# Altium schematic sheet 05_LVDC_SAMPLE.SchDoc
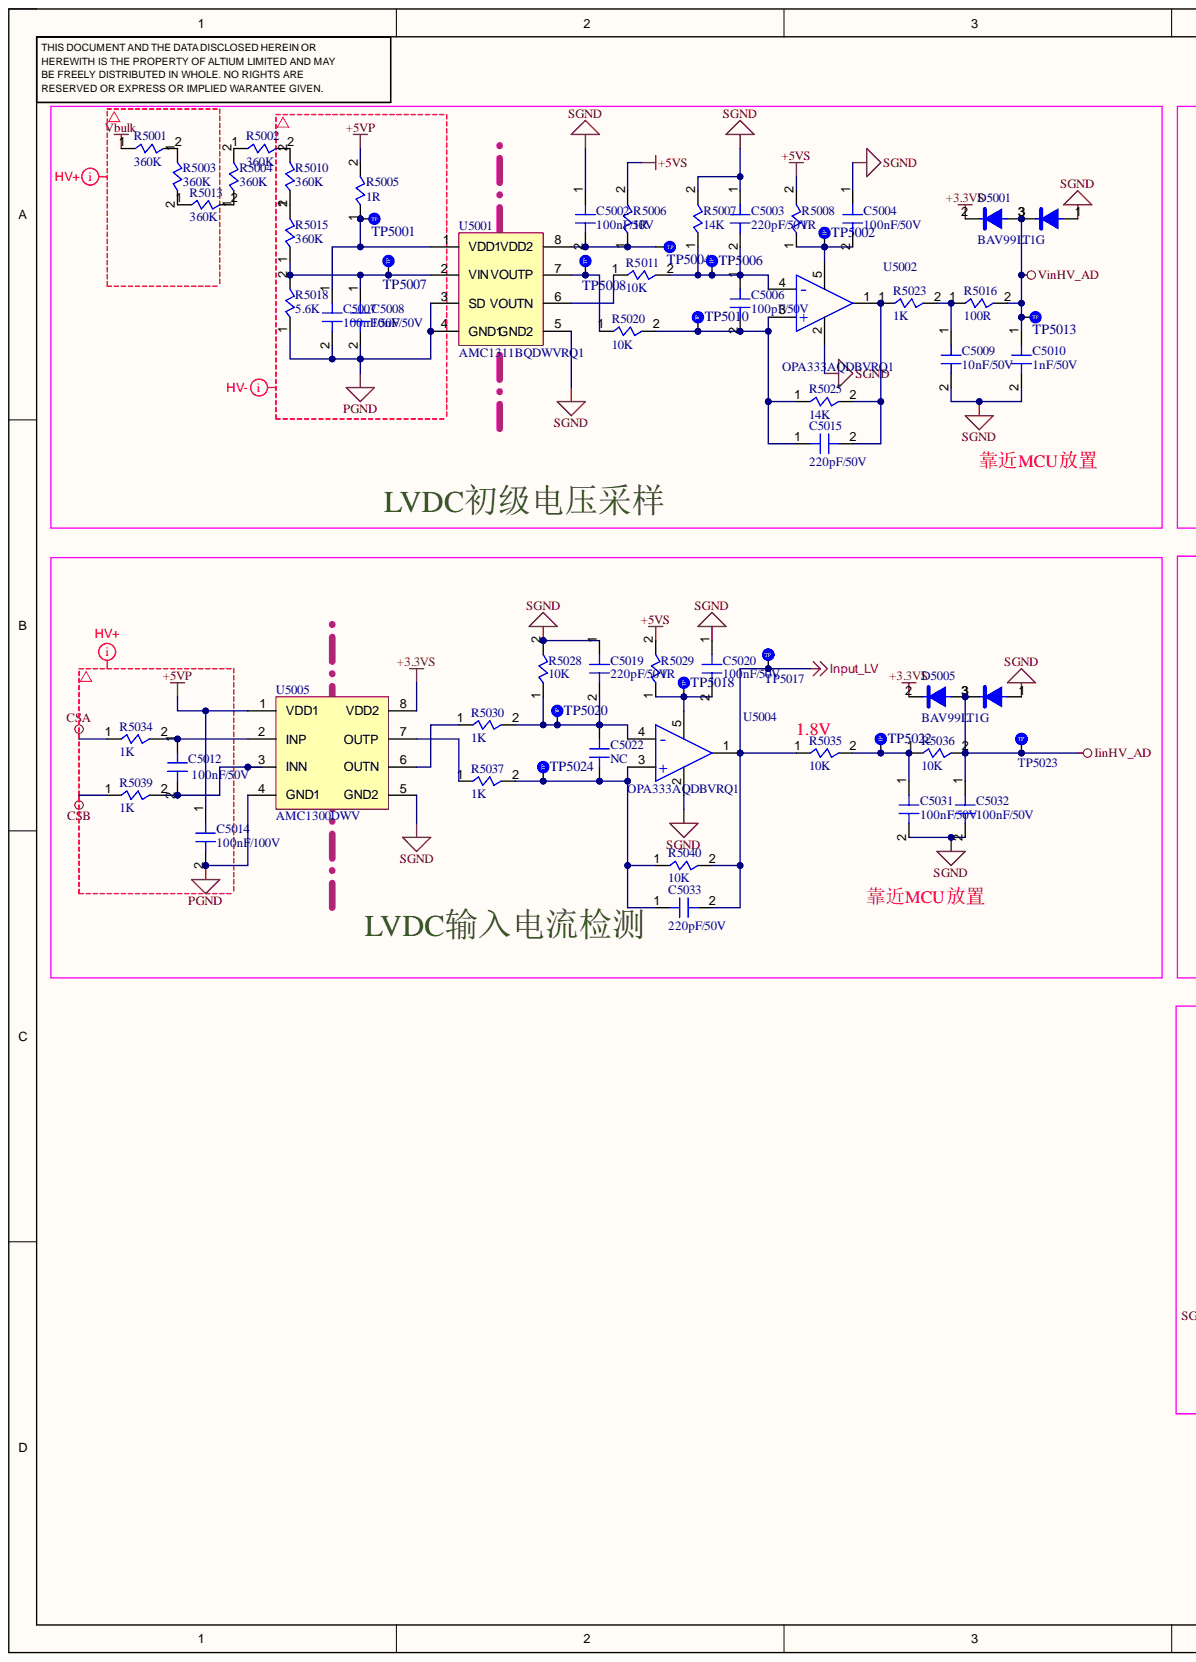
[diagram p0: 05_LVDC_SAMPLE.SchDoc, left]
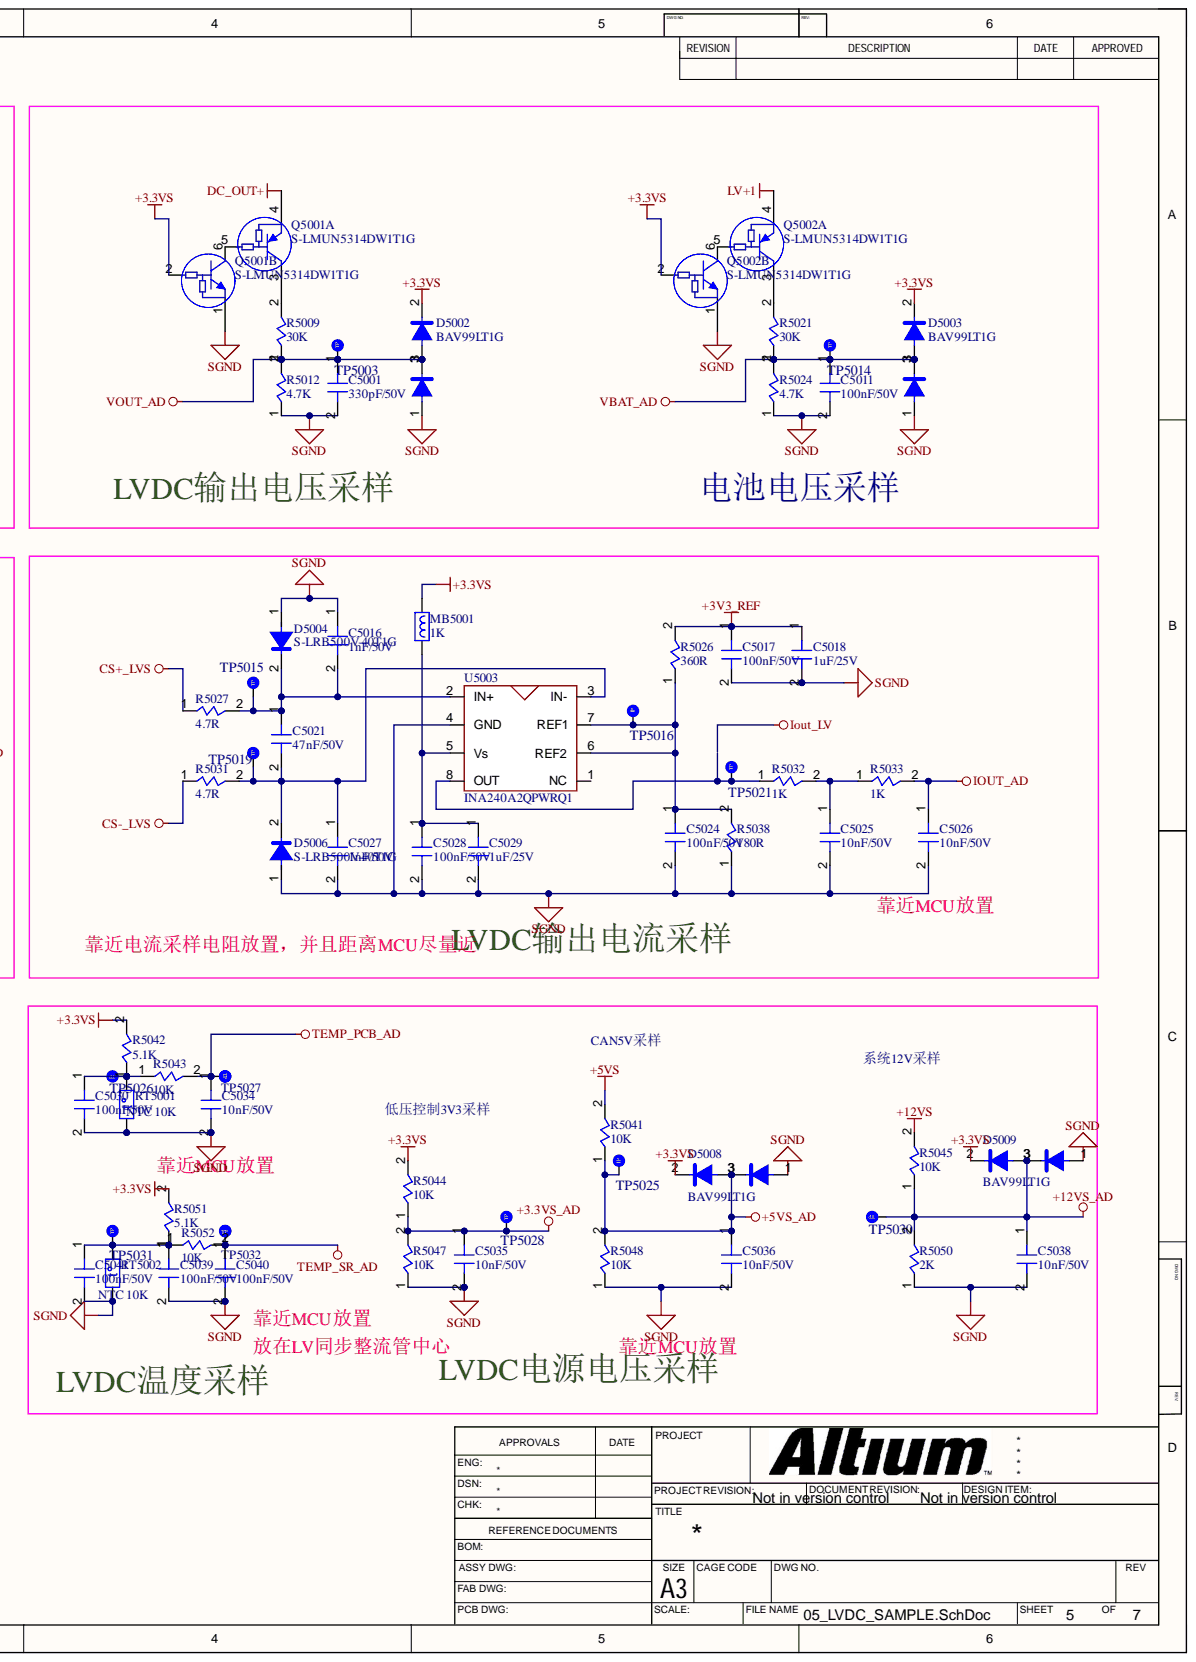
[diagram p1: 05_LVDC_SAMPLE.SchDoc, right]

## Parts
C5001  330pF  value 330pF/50V  [C-0603]
C5002  100nF  value 100nF/50V  [C-0402]
C5003  220pF  value 220pF/50V  [C-0402]
C5004  100nF  value 100nF/50V  [C-0402]
C5006  100pF  value 100pF/50V  [C-0402]
C5007  100nF  value 100nF/50V  [C-0402]
C5008  10nF  value 10nF/50V  [C-0402]
C5009  10nF  value 10nF/50V  [C-0402]
C5010  1nF  value 1nF/50V  [C-0402]
C5011  100nF  value 100nF/50V  [C-0402]
C5012  100nF  value 100nF/50V  [C-0402]
C5014  100nF/100V  [C-0603]
C5015  220pF  value 220pF/50V  [C-0402]
C5016  1nF  value 1nF/50V  [C-0402]
C5017  100nF  value 100nF/50V  [C-0402]
C5018  1uF  value 1uF/25V  [C-0603]
C5019  220pF  value 220pF/50V  [C-0402]
C5020  100nF  value 100nF/50V  [C-0402]
C5021  47nF  value 47nF/50V  [C-0402]
C5022  NC  [C-0402]  (no BOM)
C5024  100nF  value 100nF/50V  [C-0402]
C5025  10nF  value 10nF/50V  [C-0402]
C5026  10nF  value 10nF/50V  [C-0402]
C5027  1nF  value 1nF/50V  [C-0402]
C5028  100nF  value 100nF/50V  [C-0402]
C5029  1uF  value 1uF/25V  [C-0603]
C5030  100nF  value 100nF/50V  [C-0402]
C5031  100nF  value 100nF/50V  [C-0402]
C5032  100nF  value 100nF/50V  [C-0402]
C5033  220pF  value 220pF/50V  [C-0402]
C5034  10nF  value 10nF/50V  [C-0402]
C5035  10nF  value 10nF/50V  [C-0402]
C5036  10nF  value 10nF/50V  [C-0402]
C5038  10nF  value 10nF/50V  [C-0402]
C5039  100nF  value 100nF/50V  [C-0402]
C5040  100nF  value 100nF/50V  [C-0402]
C5041  100nF  value 100nF/50V  [C-0402]
D5001  BAV99LT1G  [SOT-23]
D5002  BAV99LT1G  [SOT-23]
D5003  BAV99LT1G  [SOT-23]
D5004  S-LRB500V-40T1G  [SOD-323]
D5005  BAV99LT1G  [SOT-23]
D5006  S-LRB500V-40T1G  [SOD-323]
D5008  BAV99LT1G  [SOT-23]
D5009  BAV99LT1G  [SOT-23]
MB5001  1K  [L-0805]
Q5001  S-LMUN5314DW1T1G  [SOT-363]  (parts A,B)
Q5002  S-LMUN5314DW1T1G  [SOT-363]  (parts A,B)
R5001  360K  [R-1206]
R5002  360K  [R-1206]
R5003  360K  [R-1206]
R5004  360K  [R-1206]
R5005  1R  [R-0603]
R5006  1R  [R-0603]
R5007  14K  [R-0402]
R5008  1R  [R-0603]
R5009  30K  [R-0603]
R5010  360K  [R-1206]
R5011  10K  [R-0402]
R5012  4.7K  [R-0402]
R5013  360K  [R-1206]
R5015  360K  [R-1206]
R5016  100R  [R-0402]
R5018  5.6K  [R-1206]
R5020  10K  [R-0402]
R5021  30K  [R-0603]
R5023  1K  [R-0603]
R5024  4.7K  [R-0402]
R5025  14K  [R-0402]
R5026  360R  [R-0603]
R5027  4.7R  [R-0603]
R5028  10K  [R-0402]
R5029  1R  [R-0603]
R5030  1K  [R-0402]
R5031  4.7R  [R-0603]
R5032  1K  [R-0402]
R5033  1K  [R-0402]
R5034  1K  [R-0402]
R5035  10K  [R-0402]
R5036  10K  [R-0402]
R5037  1K  [R-0402]
R5038  180R  [R-0603]
R5039  1K  [R-0402]
R5040  10K  [R-0402]
R5041  10K  [R-0402]
R5042  5.1K  [R-0402]
R5043  10K  [R-0402]
R5044  10K  [R-0402]
R5045  10K  [R-0402]
R5047  10K  [R-0402]
R5048  10K  [R-0402]
R5050  2K  [R-0402]
R5051  5.1K  [R-0402]
R5052  10K  [R-0402]
RT5001  NTC 10K  [R-0603]
RT5002  NTC 10K  [R-0603]
TP5001  TEST PAD-0.7  [STP-0.7M]  (value not drawn)  (no BOM)
TP5002  TEST PAD-0.7  [STP-0.7M]  (value not drawn)  (no BOM)
TP5003  TEST PAD-0.7  [STP-0.7M]  (value not drawn)  (no BOM)
TP5004  TEST PAD-0.7  [STP-0.7M]  (value not drawn)  (no BOM)
TP5006  TEST PAD-0.7  [STP-0.7M]  (value not drawn)  (no BOM)
TP5007  TEST PAD-0.7  [STP-0.7M]  (value not drawn)  (no BOM)
TP5008  TEST PAD-0.7  [STP-0.7M]  (value not drawn)  (no BOM)
TP5010  TEST PAD-0.7  [STP-0.7M]  (value not drawn)  (no BOM)
TP5013  TEST PAD-0.7  [STP-0.7M]  (value not drawn)  (no BOM)
TP5014  TEST PAD-0.7  [STP-0.7M]  (value not drawn)  (no BOM)
TP5015  TEST PAD-0.7  [STP-0.7M]  (value not drawn)  (no BOM)
TP5016  TEST PAD-0.7  [STP-0.7M]  (value not drawn)  (no BOM)
TP5017  TP1.0  [TP1.0]  (value not drawn)  (no BOM)
TP5018  TEST PAD-0.7  [STP-0.7M]  (value not drawn)  (no BOM)
TP5019  TEST PAD-0.7  [STP-0.7M]  (value not drawn)  (no BOM)
TP5020  TEST PAD-0.7  [STP-0.7M]  (value not drawn)  (no BOM)
TP5021  TEST PAD-0.7  [STP-0.7M]  (value not drawn)  (no BOM)
TP5022  TEST PAD-0.7  [STP-0.7M]  (value not drawn)  (no BOM)
TP5023  TP1.0  [TP1.0]  (value not drawn)  (no BOM)
TP5024  TEST PAD-0.7  [STP-0.7M]  (value not drawn)  (no BOM)
TP5025  TEST PAD-0.7  [STP-0.7M]  (value not drawn)  (no BOM)
TP5026  TEST PAD-0.7  [STP-0.7M]  (value not drawn)  (no BOM)
TP5027  TP1.0  [TP1.0]  (value not drawn)  (no BOM)
TP5028  TEST PAD-0.7  [STP-0.7M]  (value not drawn)  (no BOM)
TP5030  TEST PAD-0.7  [STP-0.7M]  (value not drawn)  (no BOM)
TP5031  TEST PAD-0.7  [STP-0.7M]  (value not drawn)  (no BOM)
TP5032  TP1.0  [TP1.0]  (value not drawn)  (no BOM)
U5001  AMC1311BQDWVRQ1  [SOIC-8W]
U5002  OPA333AQDBVRQ1  [SOT-23-5]
U5003  INA240A2QPWRQ1  [TSSOP-8]
U5004  OPA333AQDBVRQ1  [SOT-23-5]
U5005  AMC1300DWV  [SOIC-8W]

## Nets
+3.3VS: D5001.2 D5002.2 D5003.2 D5005.2 D5008.2 D5009.2 MB5001.2 Q5001.2 Q5002.2 R5042.2 R5044.2 R5051.2 U5005.8(VDD2)
+3.3VS_AD: C5035.1 R5044.1 R5047.2 TP5028.1
+3V3_REF: C5017.1 C5018.1 R5026.2
+5VP: C5014.1 R5005.2 U5005.1(VDD1)
+5VS: R5006.2 R5008.2 R5029.2 R5041.2
+5VS_AD: C5036.1 D5008.3 R5041.1 R5048.2 TP5025.1
+12VS: R5045.2
+12VS_AD: C5038.1 D5009.3 R5045.1 R5050.2 TP5030.1
CS+_LVS: R5027.1
CS-_LVS: R5031.1
CSA: R5034.1
CSB: R5039.1
DC_OUT+: Q5001.4
IinHV_AD: C5032.1 D5005.3 R5036.2 TP5023.1
Input_LV: C5033.2 R5035.1 R5040.2 TP5017.1 U5004.1
IOUT_AD: C5026.1 R5033.2
LV+1: Q5002.4
PGND: C5007.2 C5008.2 C5014.2 R5018.1 U5001.3(SD) U5001.4(GND1) U5005.4(GND1)
SGND: C5001.2 C5002.1 C5003.1 C5004.1 C5009.2 C5010.2 C5011.2 C5016.1 C5017.2 C5018.2 C5019.1 C5020.1 C5024.2 C5025.2 C5026.2 C5027.2 C5028.2 C5029.2 C5030.2 C5031.2 C5032.2 C5034.2 C5035.2 C5036.2 C5038.2 C5039.2 C5040.2 C5041.2 D5001.1 D5002.1 D5003.1 D5004.1 D5005.1 D5006.1 D5008.1 D5009.1 Q5001.1 Q5002.1 R5007.2 R5012.1 R5024.1 R5028.2 R5038.1 R5047.1 R5048.1 R5050.1 RT5001.2 RT5002.2 U5001.5(GND2) U5002.2 U5003.4(GND) U5004.2 U5005.5(GND2)
TEMP_PCB_AD: C5034.1 R5043.2 TP5027.1
TEMP_SR_AD: C5040.1 R5052.2 TP5032.1
VBAT_AD: C5011.1 D5003.3 R5021.1 R5024.2 TP5014.1
Vbulk: R5001.1
VinHV_AD: C5010.1 D5001.3 R5016.2 TP5013.1
VOUT_AD: C5001.1 D5002.3 R5009.1 R5012.2 TP5003.1
NetC5002_2: C5002.2 R5006.1 TP5004.1 U5001.8(VDD2)
NetC5003_2: C5003.2 C5006.1 R5007.1 R5011.2 TP5006.1 U5002.4(-)
NetC5004_2: C5004.2 R5008.1 TP5002.1 U5002.5
NetC5006_2: C5006.2 C5015.1 R5020.2 R5025.1 TP5010.1 U5002.3(+)
NetC5007_1: C5007.1 R5005.1 TP5001.1 U5001.1(VDD1)
NetC5008_1: C5008.1 R5015.1 R5018.2 TP5007.1 U5001.2(VIN)
NetC5009_1: C5009.1 R5016.1 R5023.2
NetC5012_1: C5012.1 R5034.2 U5005.2(INP)
NetC5012_2: C5012.2 R5039.2 U5005.3(INN)
NetC5015_2: C5015.2 R5023.1 R5025.2 U5002.1
NetC5016_2: C5016.2 C5021.1 D5004.2 R5027.2 TP5015.1 U5003.2(IN+)
NetC5019_2: C5019.2 C5022.2 R5028.1 R5030.2 TP5020.1 U5004.4(-)
NetC5020_2: C5020.2 R5029.1 TP5018.1 U5004.5
NetC5021_2: C5021.2 C5027.1 D5006.2 R5031.2 TP5019.1 U5003.3(IN-)
NetC5022_1: C5022.1 C5033.1 R5037.2 R5040.1 TP5024.1 U5004.3(+)
NetC5024_1: C5024.1 R5026.1 R5038.2 TP5016.1 U5003.6(REF2) U5003.7(REF1)
NetC5025_1: C5025.1 R5032.2 R5033.1
NetC5028_1: C5028.1 C5029.1 MB5001.1 U5003.5(Vs)
NetC5030_1: C5030.1 R5042.1 R5043.1 RT5001.1 TP5026.1
NetC5031_1: C5031.1 R5035.2 R5036.1 TP5022.1
NetC5039_1: C5039.1 C5041.1 R5051.1 R5052.1 RT5002.1 TP5031.1
NetQ5001_3: Q5001.3 R5009.2
NetQ5001_5: Q5001.5 Q5001.6
NetQ5002_3: Q5002.3 R5021.2
NetQ5002_5: Q5002.5 Q5002.6
NetR5001_2: R5001.2 R5003.1
NetR5002_1: R5002.1 R5004.2
NetR5002_2: R5002.2 R5010.2
NetR5003_2: R5003.2 R5013.1
NetR5004_1: R5004.1 R5013.2
NetR5010_1: R5010.1 R5015.2
NetR5011_1: R5011.1 U5001.6(VOUTN)
NetR5020_1: R5020.1 TP5008.1 U5001.7(VOUTP)
NetR5030_1: R5030.1 U5005.6(OUTN)
NetR5032_1: R5032.1 TP5021.1 U5003.8(OUT)
NetR5037_1: R5037.1 U5005.7(OUTP)
Iout_LV:

Not connected: U5003 1(NC)

## Library data of the parts
C5001 = 1101022004-00: 贴片电容封装; Brand: YAGEO; Database Table Name: Capacitor-SMD; Grade: 汽车级; Material Name: Capacitor; Spec/Modle: Capacitor-MLCC-0603-X7R-±10%-50V-330pF; Temprature: -55℃ to +125℃; Type: 贴片; Vendor No: AC0603KRX7R9BB331; Component Kind: Standard; Library Name: Database_Libs1.DbLib; Material No: 1101022004-00; Value2: 330pF
C5002 C5004 C5007 C5011 C5012 C5017 C5020 C5024 C5028 C5030-C5032 C5039-C5041 = 1101012028-00: 贴片电容封装; Brand: YAGEO; Database Table Name: Capacitor-SMD; Grade: 汽车级; Material Name: Capacitor; SAP Number: 09701-715/0000; Spec/Modle: Capacitor-MLCC-0402-X7R-±10%-50V-100nF; Temprature: -55℃ to +125℃; Type: 贴片; Vendor No: AC0402KRX7R9BB104; Component Kind: Standard; Library Name: Database_Libs1.DbLib; Material No: 1101012028-00; Value2: 100nF
C5003 C5015 C5019 C5033 = 1101012003-00: 贴片电容封装; Brand: YAGEO; Database Table Name: Capacitor-SMD; Grade: 汽车级; Material Name: Capacitor; SAP Number: 11003-598/0000; Spec/Modle: Capacitor-MLCC-0402-X7R-±10%-50V-220pF; Temprature: -55℃ to +125℃; Type: 贴片; Vendor No: AC0402KRX7R9BB221; Component Kind: Standard; Library Name: Database_Libs1.DbLib; Material No: 1101012003-00; Value2: 220pF
C5006 = 1101012001-00: 贴片电容封装; Brand: YAGEO; Database Table Name: Capacitor-SMD; Grade: 汽车级; Material Name: Capacitor; Spec/Modle: Capacitor-MLCC-0402-X7R-±10%-50V-100pF; Temprature: -55℃ to +125℃; Type: 贴片; Vendor No: AC0402KRX7R9BB101; Component Kind: Standard; Library Name: Database_Libs1.DbLib; Material No: 1101012001-00; Value2: 100pF
C5008 C5009 C5025 C5026 C5034-C5036 C5038 = 1101012019-00: 贴片电容封装; Brand: YAGEO; Database Table Name: Capacitor-SMD; Grade: 汽车级; Material Name: Capacitor; SAP Number: 09701-712/0000; Spec/Modle: Capacitor-MLCC-0402-X7R-±10%-50V-10nF; Temprature: -55℃ to +125℃; Type: 贴片; Vendor No: AC0402KRX7R9BB103; Component Kind: Standard; Library Name: Database_Libs1.DbLib; Material No: 1101012019-00; Value2: 10nF
C5010 C5016 C5027 = 1101012010-00: 贴片电容封装; Brand: YAGEO; Database Table Name: Capacitor-SMD; Grade: 汽车级; Material Name: Capacitor; SAP Number: 09801-025/0000; Spec/Modle: Capacitor-MLCC-0402-X7R-±10%-50V-1nF; Temprature: -55℃ to +125℃; Type: 贴片; Vendor No: AC0402KRX7R9BB102; Component Kind: Standard; Library Name: Database_Libs1.DbLib; Material No: 1101012010-00; Value2: 1nF
C5014 = 1101022046-00: 贴片电容封装; Brand: YAGEO; Database Table Name: Capacitor-SMD; Grade: 汽车级; Material Name: Capacitor; Spec/Modle: Capacitor-MLCC-0603-X7R-±10%-100V-100nF; Temprature: -55℃ to +125℃; Type: 贴片; Vendor No: AC0603KRX7R0BB104; Component Kind: Standard; Library Name: Database_Libs1.DbLib; Material No: 1101022046-00; Value: 100nF/100V; Value2: 100nF/100V
C5018 C5029 = 1101022035-00: 贴片电容封装; Brand: YAGEO; Database Table Name: Capacitor-SMD; Grade: 汽车级; Material Name: Capacitor; Spec/Modle: Capacitor-MLCC-0603-X7R-±10%-25V-1uF; Temprature: -55℃ to +125℃; Type: 贴片; Vendor No: AC0603KPX7R8BB105; Component Kind: Standard; Library Name: Database_Libs1.DbLib; Material No: 1101022035-00; Value2: 1uF
C5021 = 1101012024-00: 贴片电容封装; Brand: YAGEO; Database Table Name: Capacitor-SMD; Grade: 汽车级; Material Name: Capacitor; SAP Number: 06005-359/0000; Spec/Modle: Capacitor-MLCC-0402-X7R-±10%-50V-47nF; Temprature: -55℃ to +125℃; Type: 贴片; Vendor No: AC0402KRX7R9BB473; Component Kind: Standard; Library Name: Database_Libs1.DbLib; Material No: 1101012024-00; Value2: 47nF
C5022 = CAPNP0-0402: Material Name: Capacitor; Brand: NC; Library Name: my lib.schlib; Spec/Modle: NC; Vendor No: NC
D5001-D5003 D5005 D5008 D5009 = 1203060001-00: 二极管SOT23串联封装; Brand: onsemi; Database Table Name: Diode; Grade: 工业级; SAP Number: 06005-404/0000; Spec/Modle: Diode-Schottky-SOT23-100V-0.7A-mark(A7M); Temprature: -65℃ to +150℃; Type: 贴片; Component Kind: Standard; Library Name: Database_Libs1.DbLib; Material Name: Diode; Material No: 1203060001-00; Value: BAV99LT1G; Vendor No: BAV99LT1G
D5004 D5006 = 1203020003-00: 二极管SMD-2P封装; Brand: LRC; Database Table Name: Diode; Grade: 汽车级; Spec/Modle: Diode-Schottky-SMD-SOD323-40V-100mA-mark(5); Temprature: -55℃ to +125℃; Type: 贴片; Component Kind: Standard; Library Name: Database_Libs1.DbLib; Material Name: Diode; Material No: 1203020003-00; Value: S-LRB500V-40T1G; Vendor No: S-LRB500V-40T1G
MB5001 = 1503011001-00: 磁珠; Brand: 风华; Database Table Name: Magnetic; Grade: 工业级; Material Name: Inductor; Spec/Modle: Inductor-Magnetic bead-0805-±25%-1kΩ-1A-0.25Ω; Temprature: -55℃ to +85℃; Vendor No: CBW201209U102T; Component Kind: Standard; Library Name: Database_Libs1.DbLib; Material No: 1503011001-00; Type: 贴片; Value: 1K
Q5001 Q5002 = 1303060001-00: NPN+NPN三极管SOT363封装; Brand: LRC; Database Table Name: Transistor; Grade: 汽车级; SAP Number: 06005-422/0000; Spec/Modle: Transistor-NPN and PNP-SOT363-50V-100mA-hfe(80->)-mark(14); Temprature: -55℃ to +150℃; Type: 贴片; Component Kind: Standard; Library Name: Database_Libs1.DbLib; Material Name: Transistor; Material No: 1303060001-00; Value: S-LMUN5314DW1T1G; Vendor No: S-LMUN5314DW1T1G
R5001-R5004 R5010 R5013 R5015 = 1001040633-00: 贴片电阻封装; Brand: YAGEO; Database Table Name: Resistor-SMD; Grade: 汽车级; Material Name: Resistor; SAP Number: 05541-653/0000; Spec/Modle: Resistor-SMD-1206-1/4W-±1%-±100ppm/℃-360KΩ; Temprature: -55℃ to +155℃; Vendor No: AC1206FR-07360KL; Component Kind: Standard; Library Name: Database_Libs1.DbLib; Material No: 1001040633-00; Type: 贴片; Value: 360K
R5005 R5006 R5008 R5029 = 1001020001-00: 贴片电阻封装; Brand: YAGEO; Database Table Name: Resistor-SMD; Grade: 汽车级; Material Name: Resistor; SAP Number: 05543-021/0000; Spec/Modle: Resistor-SMD-0603-1/10W-±1%-±200ppm/℃-1Ω; Temprature: -55℃ to +155℃; Vendor No: AC0603FR-071RL; Component Kind: Standard; Library Name: Database_Libs1.DbLib; Material No: 1001020001-00; Type: 贴片; Value: 1R
R5007 R5025 = 1001010472-00: 贴片电阻封装; Brand: YAGEO; Database Table Name: Resistor-SMD; Grade: 汽车级; Material Name: Resistor; SAP Number: 05544-492/0000; Spec/Modle: Resistor-SMD-0402-1/16W-±1%-±100ppm/℃-14KΩ; Temprature: -55℃ to +155℃; Vendor No: AC0402FR-0714KL; Component Kind: Standard; Library Name: Database_Libs1.DbLib; Material No: 1001010472-00; Type: 贴片; Value: 14K
R5009 R5021 = 1001020509-00: 贴片电阻封装; Brand: YAGEO; Database Table Name: Resistor-SMD; Grade: 汽车级; Material Name: Resistor; SAP Number: 05543-529/0000; Spec/Modle: Resistor-SMD-0603-1/10W-±1%-±100ppm/℃-30KΩ; Temprature: -55℃ to +155℃; Vendor No: AC0603FR-0730KL; Component Kind: Standard; Library Name: Database_Libs1.DbLib; Material No: 1001020509-00; Type: 贴片; Value: 30K
R5011 R5020 R5028 R5035 R5036 R5040 R5041 R5043-R5045 R5047 R5048 R5052 = 1001010457-00: 贴片电阻封装; Brand: YAGEO; Database Table Name: Resistor-SMD; Grade: 汽车级; Material Name: Resistor; SAP Number: 05544-477/0000; Spec/Modle: Resistor-SMD-0402-1/16W-±1%-±100ppm/℃-10KΩ; Temprature: -55℃ to +155℃; Vendor No: AC0402FR-0710KL; Component Kind: Standard; Library Name: Database_Libs1.DbLib; Material No: 1001010457-00; Type: 贴片; Value: 10K
R5012 R5024 = 1001010419-00: 贴片电阻封装; Brand: YAGEO; Database Table Name: Resistor-SMD; Grade: 汽车级; Material Name: Resistor; SAP Number: 05544-439/0000; Spec/Modle: Resistor-SMD-0402-1/16W-±1%-±100ppm/℃-4.7KΩ; Temprature: -55℃ to +155℃; Vendor No: AC0402FR-074K7L; Component Kind: Standard; Library Name: Database_Libs1.DbLib; Material No: 1001010419-00; Type: 贴片; Value: 4.7K
R5016 = 1001010229-00: 贴片电阻封装; Brand: YAGEO; Database Table Name: Resistor-SMD; Grade: 汽车级; Material Name: Resistor; SAP Number: 05544-249/0000; Spec/Modle: Resistor-SMD-0402-1/16W-±1%-±100ppm/℃-100Ω; Temprature: -55℃ to +155℃; Vendor No: AC0402FR-07100RL; Component Kind: Standard; Library Name: Database_Libs1.DbLib; Material No: 1001010229-00; Type: 贴片; Value: 100R
R5018 = 1001040428-00: 贴片电阻封装; Brand: YAGEO; Database Table Name: Resistor-SMD; Grade: 汽车级; Material Name: Resistor; SAP Number: 05541-448/0000; Spec/Modle: Resistor-SMD-1206-1/4W-±1%-±100ppm/℃-5.6KΩ; Temprature: -55℃ to +155℃; Vendor No: AC1206FR-075K6L; Component Kind: Standard; Library Name: Database_Libs1.DbLib; Material No: 1001040428-00; Type: 贴片; Value: 5.6K
R5023 = 1001020343-00: 贴片电阻封装; Brand: YAGEO; Database Table Name: Resistor-SMD; Grade: 汽车级; Material Name: Resistor; SAP Number: 05543-363/0000; Spec/Modle: Resistor-SMD-0603-1/10W-±1%-±100ppm/℃-1KΩ; Temprature: -55℃ to +155℃; Vendor No: AC0603FR-071KL; Component Kind: Standard; Library Name: Database_Libs1.DbLib; Material No: 1001020343-00; Type: 贴片; Value: 1K
R5026 = 1001020291-00: 贴片电阻封装; Brand: YAGEO; Database Table Name: Resistor-SMD; Grade: 汽车级; Material Name: Resistor; SAP Number: 05543-311/0000; Spec/Modle: Resistor-SMD-0603-1/10W-±1%-±100ppm/℃-360Ω; Temprature: -55℃ to +155℃; Vendor No: AC0603FR-07360RL; Component Kind: Standard; Library Name: Database_Libs1.DbLib; Material No: 1001020291-00; Type: 贴片; Value: 360R
R5027 R5031 = 1001020077-00: 贴片电阻封装; Brand: YAGEO; Database Table Name: Resistor-SMD; Grade: 汽车级; Material Name: Resistor; SAP Number: 05543-097/0000; Spec/Modle: Resistor-SMD-0603-1/10W-±1%-±200ppm/℃-4.7Ω; Temprature: -55℃ to +155℃; Vendor No: AC0603FR-074R7L; Component Kind: Standard; Library Name: Database_Libs1.DbLib; Material No: 1001020077-00; Type: 贴片; Value: 4.7R
R5030 R5032-R5034 R5037 R5039 = 1001010343-00: 贴片电阻封装; Brand: YAGEO; Database Table Name: Resistor-SMD; Grade: 汽车级; Material Name: Resistor; SAP Number: 05544-363/0000; Spec/Modle: Resistor-SMD-0402-1/16W-±1%-±100ppm/℃-1KΩ; Temprature: -55℃ to +155℃; Vendor No: AC0402FR-071KL; Component Kind: Standard; Library Name: Database_Libs1.DbLib; Material No: 1001010343-00; Type: 贴片; Value: 1K
R5038 = 1001020256-00: 贴片电阻封装; Brand: YAGEO; Database Table Name: Resistor-SMD; Grade: 汽车级; Material Name: Resistor; SAP Number: 05543-276/0000; Spec/Modle: Resistor-SMD-0603-1/10W-±1%-±100ppm/℃-180Ω; Temprature: -55℃ to +155℃; Vendor No: AC0603FR-07180RL; Component Kind: Standard; Library Name: Database_Libs1.DbLib; Material No: 1001020256-00; Type: 贴片; Value: 180R
R5042 R5051 = 1001010423-00: 贴片电阻封装; Brand: YAGEO; Database Table Name: Resistor-SMD; Grade: 汽车级; Material Name: Resistor; SAP Number: 05544-443/0000; Spec/Modle: Resistor-SMD-0402-1/16W-±1%-±100ppm/℃-5.1KΩ; Temprature: -55℃ to +155℃; Vendor No: AC0402FR-075K1L; Component Kind: Standard; Library Name: Database_Libs1.DbLib; Material No: 1001010423-00; Type: 贴片; Value: 5.1K
R5050 = 1001010375-00: 贴片电阻封装; Brand: YAGEO; Database Table Name: Resistor-SMD; Grade: 汽车级; Material Name: Resistor; SAP Number: 05544-395/0000; Spec/Modle: Resistor-SMD-0402-1/16W-±1%-±100ppm/℃-2KΩ; Temprature: -55℃ to +155℃; Vendor No: AC0402FR-072KL; Component Kind: Standard; Library Name: Database_Libs1.DbLib; Material No: 1001010375-00; Type: 贴片; Value: 2K
RT5001 RT5002 = RT-DHT: Brand: THINKING; Material Name: Temperature sensor; Material No: 2010000010-00; SAP Number: 06005-223/0000; Spec/Modle: SMD,RES,NTC,0603,10K±1%; Vendor No: TSM1C103F34D1R; Component Kind: Standard; Library Name: P08002_Main.SCHLIB
TP5017 TP5023 TP5027 TP5032 = TP: Library Name: my lib.schlib
U5001 = 1403040001-00: 芯片，隔离运放; Brand: IT; Database Table Name: IC; Grade: 汽车级; SAP Number: 05529-624/0000; Spec/Modle: IC-Isolated Amplifier-SOIC8-250kHz-1:1-VCC（3→5.5)-mark(1311BQ1); Temprature: -40℃ to +125℃; Component Kind: Standard; Library Name: Database_Libs1.DbLib; Material Name: IC; Material No: 1403040001-00; Type: 贴片; Value: AMC1311BQDWVRQ1; Vendor No: AMC1311BQDWVRQ1
U5002 U5004 = 1403010001-00: 芯片，单路比较器; Brand: TI; Database Table Name: IC; Grade: 汽车级; Spec/Modle: IC-Amplifier-SOT23-5-350KHz-VCC(1.8V→5.5V)-mark(QCNQ); Temprature: -40℃ to +125℃; Component Kind: Standard; Library Name: Database_Libs1.DbLib; Material Name: IC; Material No: 1403010001-00; Type: 贴片; Value: OPA333AQDBVRQ1; Vendor No: OPA333AQDBVRQ1
U5003 = 1403010003-00: 芯片，电流采样芯片; Brand: TI; Database Table Name: IC; Grade: 汽车级; Spec/Modle: IC-Current Amplifier-TSSOP8-50V/V-VCC（2.7~5.5V)-mark(Q240A3); Temprature: -40℃ to +125℃; Vendor No: INA240A3QPWRQ1; Component Kind: Standard; Library Name: Database_Libs1.DbLib; Material Name: IC; Material No: 1403010003-00; Type: 贴片; Value: INA240A2QPWRQ1
U5005 = 1403010004-00: Brand: TI; Database Table Name: IC; Grade: 汽车级; Spec/Modle: IC-Current Amplifier-SOIC8W-8.2V/V-VCC(4.5→5.5V)→mark(AMC1300); Temprature: -40℃ to +125℃; Component Kind: Standard; Library Name: Database_Libs1.DbLib; Material Name: IC; Material No: 1403010004-00; Type: 贴片; Value: AMC1300DWV; Vendor No: AMC1300DWV
TP5001-TP5004 TP5006-TP5008 TP5010 TP5013-TP5016 TP5018-TP5022 TP5024-TP5026 TP5028 TP5030 TP5031 = TEST PAD-0.7: Library Name: Test Pad.SchLib
